# Altium schematic sheet [12] - POWER.SchDoc
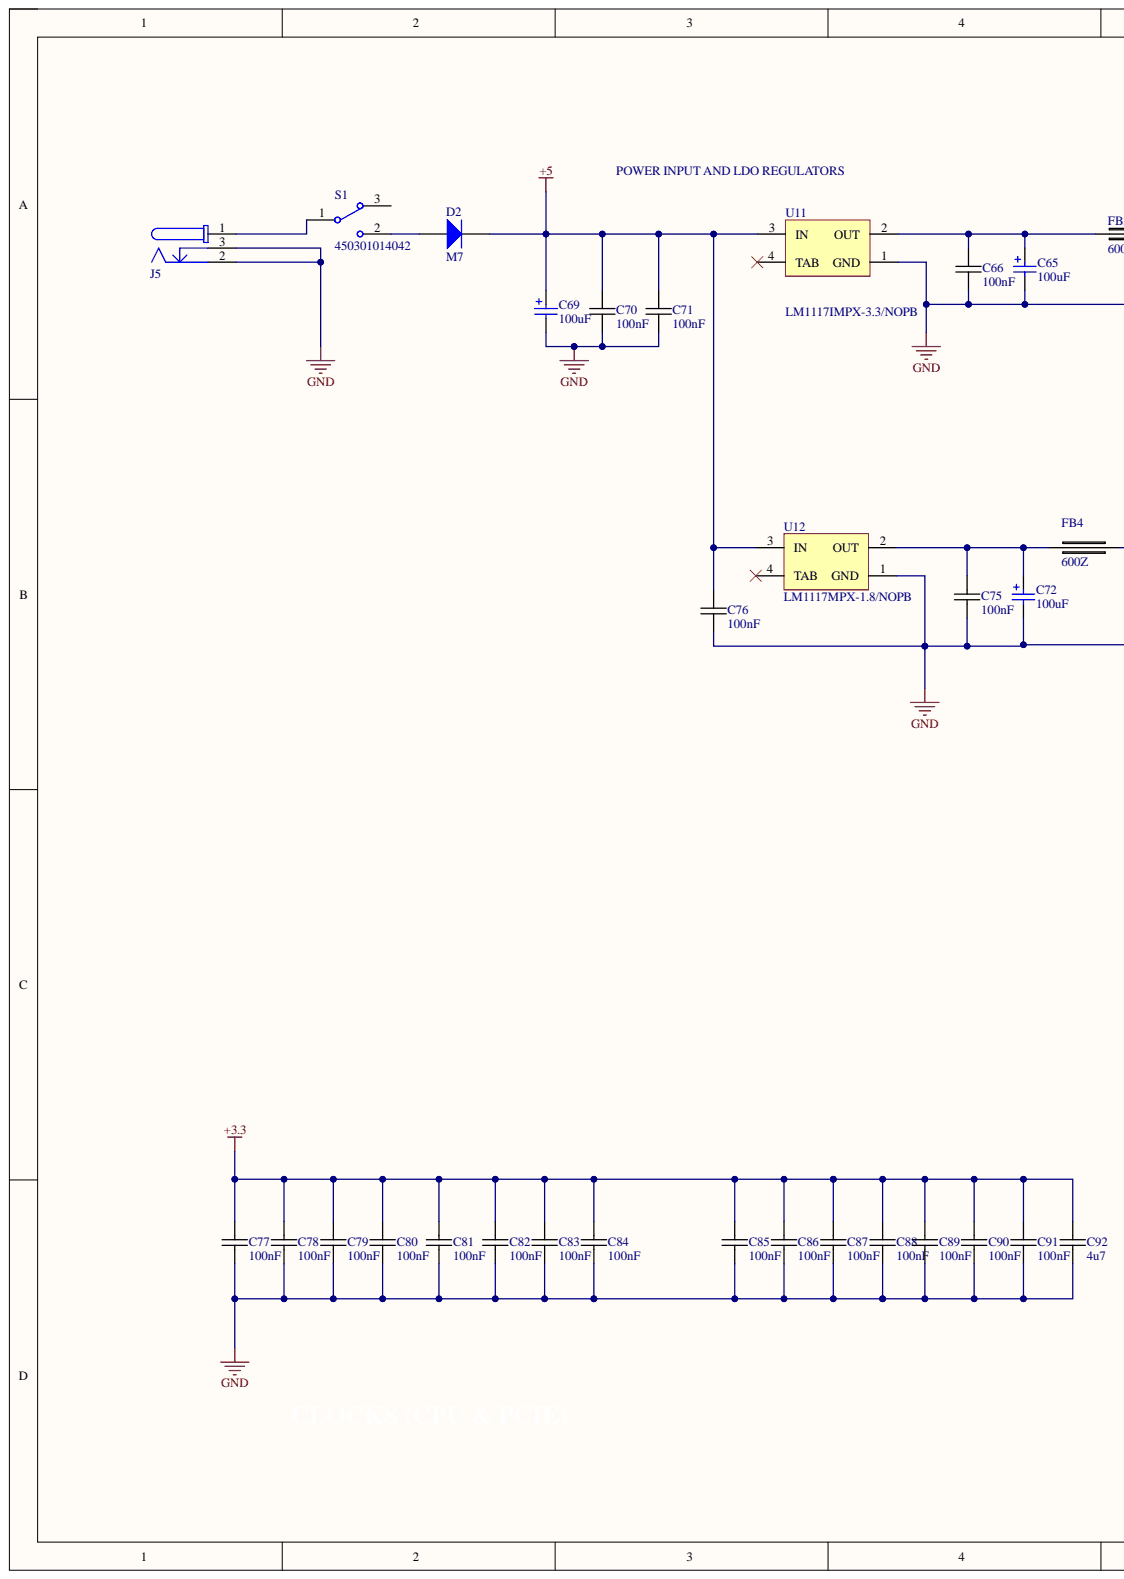
[diagram p0: [12] - POWER.SchDoc, left]
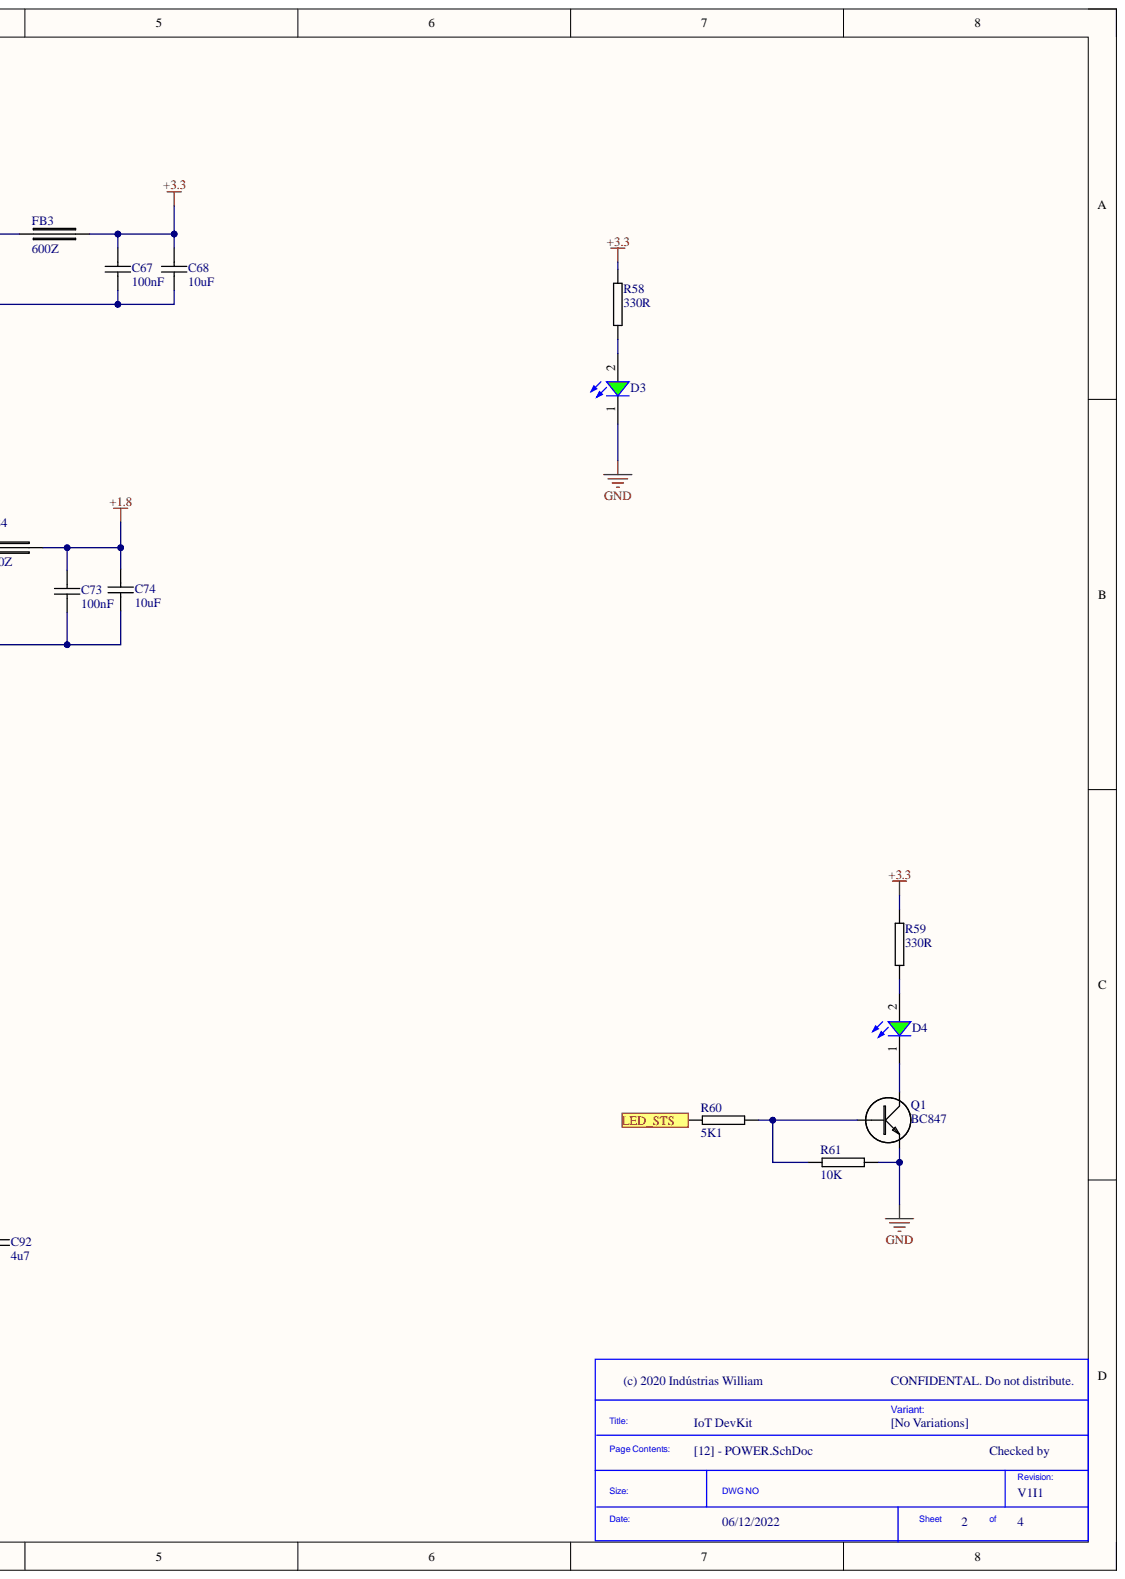
[diagram p1: [12] - POWER.SchDoc, right]

## Parts
C65  value 100uF  [WCAP-PSLP_5x5.8]  (hidden comment: 875105359001)
C66  100nF  [0603C-GENERIC]
C67  100nF  [0603C-GENERIC]
C68  10uF  [0603C-GENERIC]
C69  value 100uF  [WCAP-PSLP_5x5.8]  (hidden comment: 875105359001)
C70  100nF  [0603C-GENERIC]
C71  100nF  [0603C-GENERIC]
C72  value 100uF  [WCAP-PSLP_5x5.8]  (hidden comment: 875105359001)
C73  100nF  [0603C-GENERIC]
C74  10uF  [0603C-GENERIC]
C75  100nF  [0603C-GENERIC]
C76  100nF  [0603C-GENERIC]
C77  100nF  [0603C-GENERIC]
C78  100nF  [0603C-GENERIC]
C79  100nF  [0603C-GENERIC]
C80  100nF  [0603C-GENERIC]
C81  100nF  [0603C-GENERIC]
C82  100nF  [0603C-GENERIC]
C83  100nF  [0603C-GENERIC]
C84  100nF  [0603C-GENERIC]
C85  100nF  [0603C-GENERIC]
C86  100nF  [0603C-GENERIC]
C87  100nF  [0603C-GENERIC]
C88  100nF  [0603C-GENERIC]
C89  100nF  [0603C-GENERIC]
C90  100nF  [0603C-GENERIC]
C91  100nF  [0603C-GENERIC]
C92  4u7  [0603C-GENERIC]
D2  M7  [DO-214AC(SMA)]
D3  Green, 3.2 V, 140 deg  [WL-SMCW_0603_H=0.7]  (value not drawn)
D4  Green, 3.2 V, 140 deg  [WL-SMCW_0603_H=0.7]  (value not drawn)
FB3  600Z  [0805FB-600Z]
FB4  600Z  [0805FB-600Z]
J5  694102303002  [694102303002]  (value not drawn)
Q1  BC847  [SOT23-BCE]
R58  330R  [0603R-330]
R59  330R  [0603R-330]
R60  5K1  [0603R-GENERIC]
R61  10K  [0603R-10K]
S1  450301014042  [450301014042]
U11  LM1117IMPX-3.3/NOPB  [MP04A_N]
U12  LM1117MPX-1.8/NOPB  [MP04A_N]

## Ports
LED_STS

## Nets
+1.8: C73.1 C74.1 FB4.2
+3.3: C67.1 C68.1 C77.2 C78.2 C79.2 C80.2 C81.2 C82.2 C83.2 C84.2 C85.2 C86.2 C87.2 C88.2 C89.2 C90.2 C91.2 C92.1 FB3.2 R58.1 R59.1
+5: C69.1 C70.1 C71.1 C76.1 D2.K U11.3(IN) U12.3(IN)
GND: C65.2 C66.2 C67.2 C68.2 C69.2 C70.2 C71.2 C72.2 C73.2 C74.2 C75.2 C76.2 C77.1 C78.1 C79.1 C80.1 C81.1 C82.1 C83.1 C84.1 C85.1 C86.1 C87.1 C88.1 C89.1 C90.1 C91.1 C92.2 D3.1 J5.2 J5.3 Q1.E R61.2 U11.1(GND) U12.1(GND)
LED_STS: R60.1 ; port LED_STS
NetC65_1: C65.1 C66.1 FB3.1 U11.2(OUT)
NetC72_1: C72.1 C75.1 FB4.1 U12.2(OUT)
NetD2_A: D2.A S1.2
NetD3_2: D3.2 R58.2
NetD4_1: D4.1 Q1.C
NetD4_2: D4.2 R59.2
NetJ5_1: J5.1 S1.1
NetQ1_B: Q1.B R60.2 R61.1

Not connected: S1 3; U11 4(TAB); U12 4(TAB)

## Library data of the parts
C65 C69 C72 = 875105359001: WCAP-PSLP Aluminum Polymer Capacitors, size Ф5x5.8mm, 16V DC, 10uF; Rated Voltage: 16V DC; Component Kind: Standard; ComponentLink1Description: Manufacturer; ComponentLink1URL: http://www.we-online.de; ComponentLink2Description: Datasheet; ComponentLink2URL: http://katalog.we-online.de/pbs/datasheet/875105359001.pdf; Library Name: ALTIUM-WCAP-PSLP.IntLib; Life Cycle: 2000h; Operating Temperature: -55 °C up to +105 °C; Part Number: 875105359001; Published Date: June 2017; Size: Ф5x5.8mm; Publisher: Wurth Elektronik
C66 C67 C70 C71 C73 C75-C91 = 0603C-100NF-25V-X7R-TDK: CAPACITOR 0603 CERAMIC; Manufacturer: TDK Corporation; Manufacturer Part Number: C1608X7R1E104K; Reel: C12; Component Kind: Standard; Library Name: vh_altium_library.intLib; Supplier 1: Digi-Key; Supplier Part Number 1: 445-1316-2-ND
C68 C74 = 0603C-10UF-10V-X5R-TDK: CAPACITOR 0603 CERAMIC; Manufacturer: TDK Corporation; Manufacturer Part Number: C1608X5R0J106M; Reel: C31; Component Kind: Standard; Library Name: vh_altium_library.intLib; Supplier 1: Digi-Key; Supplier Part Number 1: 445-4112-2-ND
C92 = 0603C-4U7-10V-X5R-SAM: CAPACITOR 0603 CERAMIC; Reel: C46; Component Kind: Standard; Library Name: vh_altium_library.intLib
D2 = M7: 1A Rectifier Diodes; Component Kind: Standard; Library Name: Componentes Deived Projetos.IntLib
D3 D4 = 150060GS75000: SMT Mono-color Chip LED Waterclear WL-SMCW, size 0603, Green, 3.2 V, 140 deg, 430 mcd; Chip Technology: InGaN; Dominant Wavelength: 525 nm; Forward Voltage: 3.2 V; Luminous Intensity: 430 mcd; Peak Wavelength: 515 nm; Viewing Angle: 140 deg; Component Kind: Standard; ComponentLink1Description: Manufacturer; ComponentLink1URL: http://www.we-online.de; ComponentLink2Description: Datasheet; ComponentLink2URL: https://katalog.we-online.de/led/datasheet/150060GS75000.pdf; Emitting Color: Green; Library Name: ALTIUM-WL-SMCW-rev18c.IntLib; Mounting Technology: SMT; Operating Temperature: -40 to 85 degC; Packaging: Tape and Reel; Part Number: 150060GS75000; Published Date: November 2018; Size: 0603; Publisher: Wurth Elektronik
FB3 FB4 = 0805FB-600Z: FERRITE BEAD 600 OHM 2A; Reel: F5; Component Kind: Standard; Library Name: vh_altium_library.intLib
J5 = 694102303002: DC Power Jack Right Angled(4.2) WR-DC; Opening Diameter: 4.2 mm; Plug OD: 3.5 mm; Rated Current: 2 A; Withstanding Voltage: 500 VAC; Working Voltage: 30 VAC; Component Kind: Standard; ComponentLink1Description: Manufacturer; ComponentLink1URL: http://www.we-online.de; ComponentLink2Description: Datasheet; ComponentLink2URL: http://katalog.we-online.com/em/datasheet/6941xx303002.pdf; Library Name: Altium_WR-DC_POWER_JACK_CONNECTORS (rev17a).IntLib; Part Number: 694102303002; Published Date: September 2017; Publisher: Wurth Elektronik
Q1 = BC847: BC847 SMALL SIGNAL NPN TRANSISTOR; Manufacturer: Fairchild Semiconductor; Manufacturer Part Number: BC847BMTF; Current - Collector (Ic) (Max): 100mA; DC Current Gain (hFE) (Min) @ Ic, Vce: 200 @ 2mA, 5V; Frequency - Transition: 300MHz; Power - Max: 310mW; Vce Saturation (Max) @ Ib, Ic: 200mV @ 5mA, 100mA; Voltage - Collector Emitter Breakdown (Max): 45V; Category: Discrete Semiconductor Products; Component Kind: Standard; Current - Collector Cutoff (Max): -; Library Name: vh_altium_library.intLib; Mounting Type: Surface Mount; Package / Case: SOT-23-3, TO-236-3, Micro3™, SSD3, SST3; Packaging: Cut Tape (CT); RoHS: RoHS Compliant; Supplier: Digi-Key; Supplier 1: Digi-Key; Supplier Part Number: BC847BMTFCT-ND; Supplier Part Number 1: BC847BMTFCT-ND; Transistor Type: NPN
R58 R59 = 0603R-330: GENERIC RESISTOR 0603; Manufacturer: Stackpole Electronics Inc; Manufacturer Part Number: RMCF 1/16 330 1% R; Power (Watts): 0.1W, 1/10W; Resistance In Ohms: 330; Temperature Coefficient: ±100ppm/°C; Tolerance: ±1%; Case: 0603 (1608 metric); Category: Resistors; Component Kind: Standard; Composition: Thick Film; Lead Style: Surface Mount (SMD - SMT); Library Name: vh_altium_library.intLib; Packaging: Cut Tape (CT); RoHS: RoHS Compliant; Supplier: Digi-Key; Supplier 1: Digi-Key; Supplier Part Number: RMCF1/16330FRCT-ND; Supplier Part Number 1: RMCF1/16330FRCT-ND
R60 = 0603R-5K1: GENERIC RESISTOR 0603; Manufacturer: Stackpole Electronics Inc; Manufacturer Part Number: RMCF 1/16 15K 1% R; Power (Watts): 0.1W, 1/10W; Resistance In Ohms: 15.0K; Temperature Coefficient: ±100ppm/°C; Tolerance: ±1%; Case: 0603 (1608 metric); Category: Resistors; Component Kind: Standard; Composition: Thick Film; Lead Style: Surface Mount (SMD - SMT); Library Name: vh_altium_library.intLib; Packaging: Tape & Reel (TR); RoHS: RoHS Compliant; Supplier: Digi-Key; Supplier 1: Digi-Key; Supplier Part Number: RMCF1/1615KFRTR-ND; Supplier Part Number 1: RMCF1/1615KFRTR-ND
R61 = 0603R-10K: GENERIC RESISTOR 0603; Manufacturer: Stackpole Electronics Inc; Manufacturer Part Number: RMCF 1/16 10K 1% R; Power (Watts): 0.1W, 1/10W; Reel: R21; Resistance In Ohms: 10.0K; Temperature Coefficient: ±100ppm/°C; Tolerance: ±1%; Case: 0603 (1608 metric); Category: Resistors; Component Kind: Standard; Composition: Thick Film; Lead Style: Surface Mount (SMD - SMT); Library Name: vh_altium_library.intLib; Packaging: Digi-Reel®; RoHS: RoHS Compliant; Supplier: Digi-Key; Supplier 1: Digi-Key; Supplier Part Number: RMCF1/1610KFRDKR-ND; Supplier Part Number 1: RMCF0603FT10K0DKR-ND
S1 = 450301014042: WS-SLTV THT Mini Slide Switch, Opposite Side Connection, SPDT; Rated Current: 500mA; Rated Voltage: 12VDC; Switching Function: On-On; Circuit: SPDT; Component Kind: Standard; ComponentLink1Description: Manufacturer; ComponentLink1URL: http://www.we-online.de; ComponentLink2Description: Datasheet; ComponentLink2URL: https://katalog.we-online.com/em/datasheet/450301014042.pdf; Contact Material: Copper Alloy; Contact Plating: Gold; Mounting Technology: THT; Operating Temperature: -40 to +85degC; Packaging: Bulk; Part Number: 450301014042; Published Date: September 2019; Size: 10x2.5mm; Terminal Material: Copper Alloy; Terminal Plating: Gold; Library Name: switch_on_off.IntLib; Publisher: Wurth Elektronik
U11 = LM1117IMPX-3.3/NOPB: 800mA Low-Dropout Linear Regulator, 4-pin SOT-223, Pb-Free; Manufacturer: National Semiconductor; CompType: LDO IC; PackageTopMarking: XYTT*N05B; PowerWise: N; Code_IPC: SOT223-4; Code_JEDEC: TO-261-AA; Component Kind: Standard; ComponentLink1Description: LM1117 Datasheet; ComponentLink1URL: http://www.national.com/ds/LM/LM1117.pdf; ComponentLink2Description: LM1117 Web Page; ComponentLink2URL: http://www.national.com/pf/LM/LM1117.html; ComponentLink3Description: MP04A Package Page; ComponentLink3URL: http://www.national.com/packaging/folders/mp04a.html; DatasheetVersion: Apr-2006; Library Name: NSC LDO.IntLib; PackageDescription: Molded SOT-223, 6.50 x 3.56 x 1.6mm Body, 4 Lead, 2.3mm Pitch; PackageReference: MP04A; PackageVersion: revB, Apr-2003; PartNumber: LM1117IMPX-3.3/NOPB; PinCount: 4; Published: 1-Mar-2010; RoHS: Y; Supplier 1: Digi-Key; Supplier Part Number 1: LM1117IMPX-3.3-ND; Publisher: Altium Limited
U12 = LM1117MPX-1.8/NOPB: 800mA Low-Dropout Linear Regulator, 4-pin SOT-223, Pb-Free; Manufacturer: National Semiconductor; CompType: LDO IC; PackageTopMarking: XYTT*N12A; PowerWise: N; Code_IPC: SOT223-4; Code_JEDEC: TO-261-AA; Component Kind: Standard; ComponentLink1Description: LM1117 Datasheet; ComponentLink1URL: http://www.national.com/ds/LM/LM1117.pdf; ComponentLink2Description: LM1117 Web Page; ComponentLink2URL: http://www.national.com/pf/LM/LM1117.html; ComponentLink3Description: MP04A Package Page; ComponentLink3URL: http://www.national.com/packaging/folders/mp04a.html; DatasheetVersion: Apr-2006; Library Name: NSC LDO.IntLib; PackageDescription: Molded SOT-223, 6.50 x 3.56 x 1.6mm Body, 4 Lead, 2.3mm Pitch; PackageReference: MP04A; PackageVersion: revB, Apr-2003; PartNumber: LM1117MPX-1.8/NOPB; PinCount: 4; Published: 1-Mar-2010; RoHS: Y; Supplier 1: Digi-Key; Supplier Part Number 1: LM1117MPX-1.8-ND; Publisher: Altium Limited
